# Altium schematic sheet CW341_FPGA_DECOUP.SchDoc
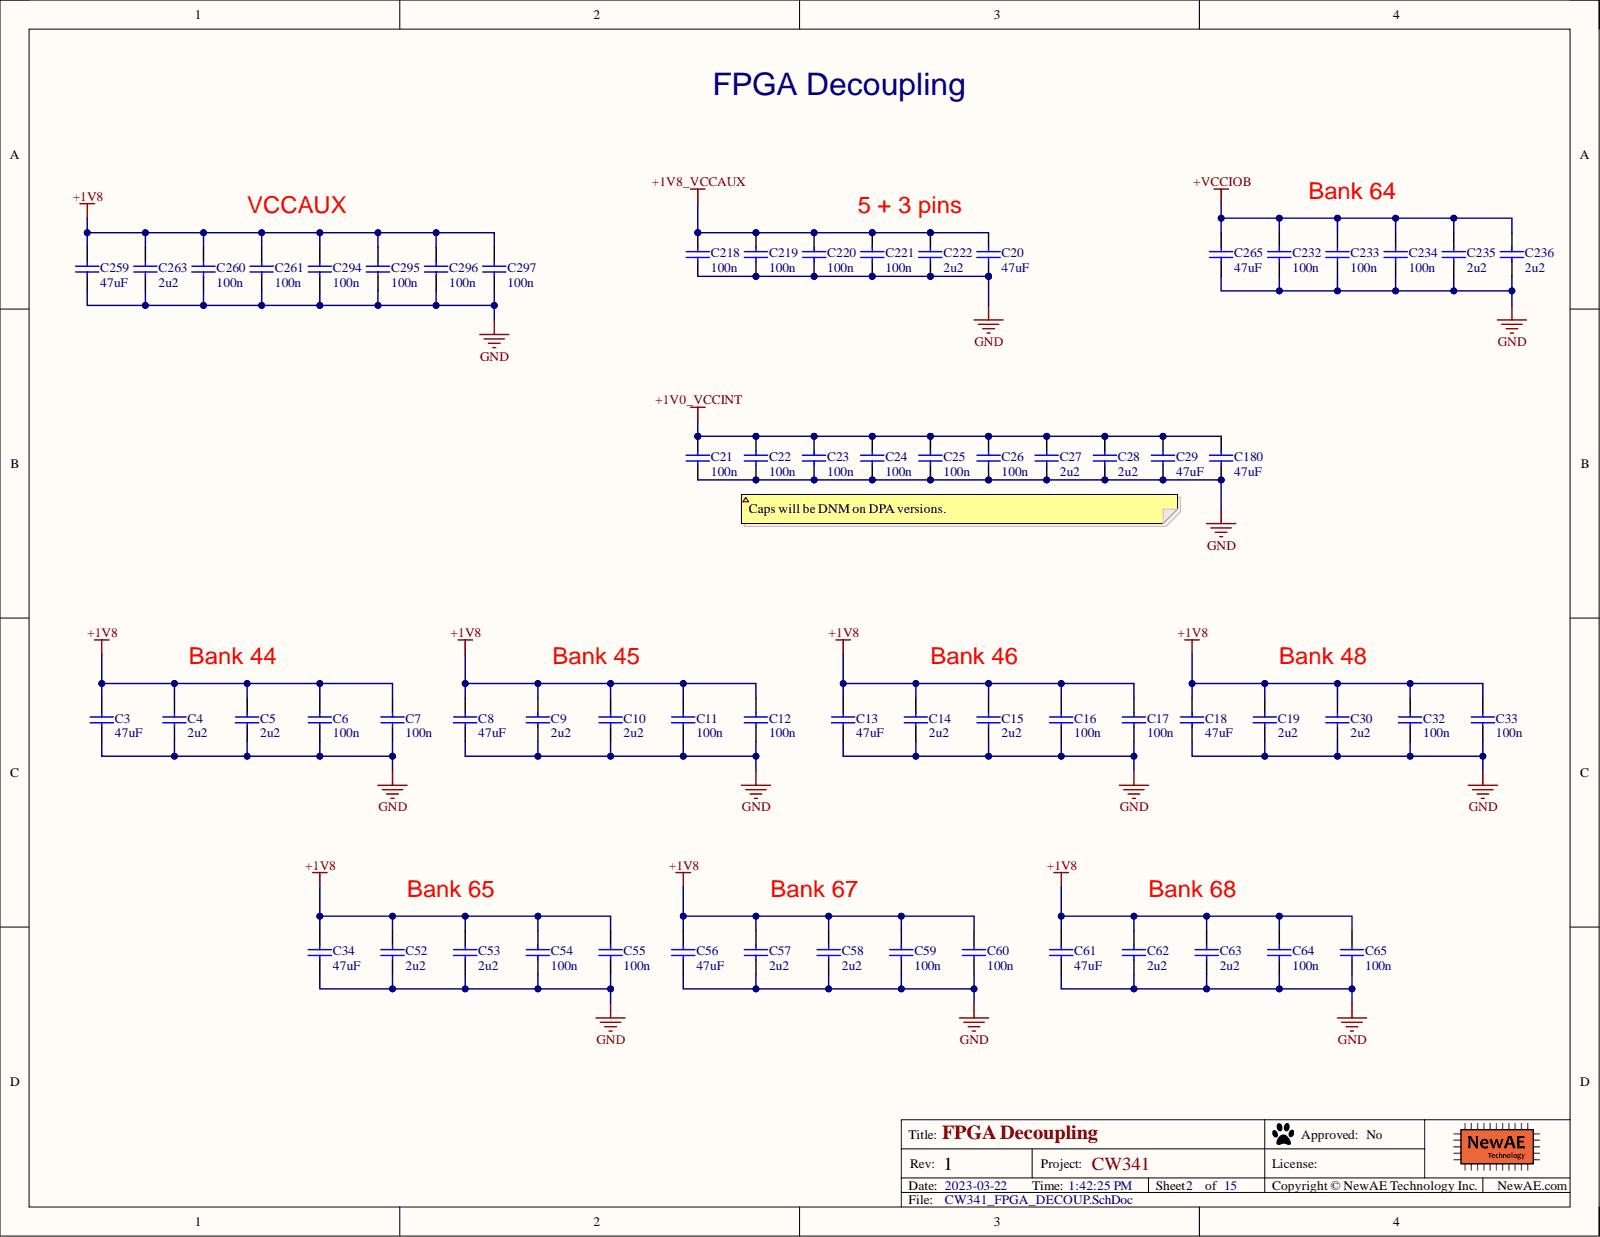
[diagram p0: CW341_FPGA_DECOUP.SchDoc]

## Parts
C3  47uF  [1210]
C4  2u2  [0603]
C5  2u2  [0603]
C6  100n  [0402]
C7  100n  [0402]
C8  47uF  [1210]
C9  2u2  [0603]
C10  2u2  [0603]
C11  100n  [0402]
C12  100n  [0402]
C13  47uF  [1210]
C14  2u2  [0603]
C15  2u2  [0603]
C16  100n  [0402]
C17  100n  [0402]
C18  47uF  [1210]
C19  2u2  [0603]
C20  47uF  [1210]
C21  100n  [0402]
C22  100n  [0402]
C23  100n  [0402]
C24  100n  [0402]
C25  100n  [0402]
C26  100n  [0402]
C27  2u2  [0603]
C28  2u2  [0603]
C29  47uF  [1210]
C30  2u2  [0603]
C32  100n  [0402]
C33  100n  [0402]
C34  47uF  [1210]
C52  2u2  [0603]
C53  2u2  [0603]
C54  100n  [0402]
C55  100n  [0402]
C56  47uF  [1210]
C57  2u2  [0603]
C58  2u2  [0603]
C59  100n  [0402]
C60  100n  [0402]
C61  47uF  [1210]
C62  2u2  [0603]
C63  2u2  [0603]
C64  100n  [0402]
C65  100n  [0402]
C180  47uF  [1210]
C218  100n  [0402]
C219  100n  [0402]
C220  100n  [0402]
C221  100n  [0402]
C222  2u2  [0603]
C232  100n  [0402]
C233  100n  [0402]
C234  100n  [0402]
C235  2u2  [0603]
C236  2u2  [0603]
C259  47uF  [1210]
C260  100n  [0402]
C261  100n  [0402]
C263  2u2  [0603]
C265  47uF  [1210]
C294  100n  [0402]
C295  100n  [0402]
C296  100n  [0402]
C297  100n  [0402]

## Nets
+1V0_VCCINT: C21.1 C22.1 C23.1 C24.1 C25.1 C26.1 C27.1 C28.1 C29.1 C180.1
+1V8: C3.1 C4.1 C5.1 C6.1 C7.1 C8.1 C9.1 C10.1 C11.1 C12.1 C13.1 C14.1 C15.1 C16.1 C17.1 C18.1 C19.1 C30.1 C32.1 C33.1 C34.1 C52.1 C53.1 C54.1 C55.1 C56.1 C57.1 C58.1 C59.1 C60.1 C61.1 C62.1 C63.1 C64.1 C65.1 C259.1 C260.1 C261.1 C263.1 C294.1 C295.1 C296.1 C297.1
+1V8_VCCAUX: C20.1 C218.1 C219.1 C220.1 C221.1 C222.1
+VCCIOB: C232.1 C233.1 C234.1 C235.1 C236.1 C265.1
GND: C3.2 C4.2 C5.2 C6.2 C7.2 C8.2 C9.2 C10.2 C11.2 C12.2 C13.2 C14.2 C15.2 C16.2 C17.2 C18.2 C19.2 C20.2 C21.2 C22.2 C23.2 C24.2 C25.2 C26.2 C27.2 C28.2 C29.2 C30.2 C32.2 C33.2 C34.2 C52.2 C53.2 C54.2 C55.2 C56.2 C57.2 C58.2 C59.2 C60.2 C61.2 C62.2 C63.2 C64.2 C65.2 C180.2 C218.2 C219.2 C220.2 C221.2 C222.2 C232.2 C233.2 C234.2 C235.2 C236.2 C259.2 C260.2 C261.2 C263.2 C265.2 C294.2 C295.2 C296.2 C297.2

## Library data of the parts
C3 C8 C13 C18 C20 C29 C34 C56 C61 C180 C259 C265 = CCHIP-47u-1210: 47u, 10V, 1210, Ceramic; Manufacture 1: TDK Corporation; Manufacture Part Number 1: C3225X5R1A476M250AC; Case-Metric: 3225; Component Group: Capacitor; Database Table Name: main; Rated Voltage: 10VDC; Tolerance: 20%; Case-EIA: 1210; Component Kind: Standard; Component Type: Standard; Library Name: NewAE_AltiumLib.DBLib; Mounting Technology: SMT; Part Number: CCHIP-47u-1210; Pin Count: 2; Supplier 1: Digi-Key; Supplier Part Number 1: 445-6711-1-ND; Temperature Range: -55C to 85C; Value: 47uF
C4 C5 C9 C10 C14 C15 C19 C27 C28 C30 C52 C53 C57 C58 C62 C63 C222 C235 C236 C263 = CCHIP-2u2-0603: 2u2, 0603; Manufacture 1: Samsung; Manufacture Part Number 1: CL10B225KP8NNNC; Case-Metric: 1608; Component Group: Capacitor; Database Table Name: main; Rated Voltage: 10VDC; Case-EIA: 0603; Component Kind: Standard; Component Type: Standard; Library Name: NewAE_AltiumLib.DBLib; Mounting Technology: SMT; Part Number: CCHIP-2u2-0603; Pin Count: 2; Supplier 1: Digi-Key; Supplier Part Number 1: 1276-1134-1-ND; Value: 2u2
C6 C7 C11 C12 C16 C17 C21-C26 C32 C33 C54 C55 C59 C60 C64 C65 C218-C221 C232-C234 C260 C261 C294-C297 = CCHIP-100n-0402: 100n, 0402; Manufacture Part Number 1: CGA2B3X7R1V104K050BB; Component Group: Capacitor; Database Table Name: main; Case-EIA: 0402; Component Kind: Standard; Component Type: Standard; Library Name: NewAE_AltiumLib.DBLib; Mounting Technology: SMT; Part Number: CCHIP-100n-0402; Pin Count: 2; Supplier 1: Digi-Key; Supplier Part Number 1: 445-6901-1-ND; Value: 100n
